# Altium schematic sheet STC32G&STC8H Core.SchDoc
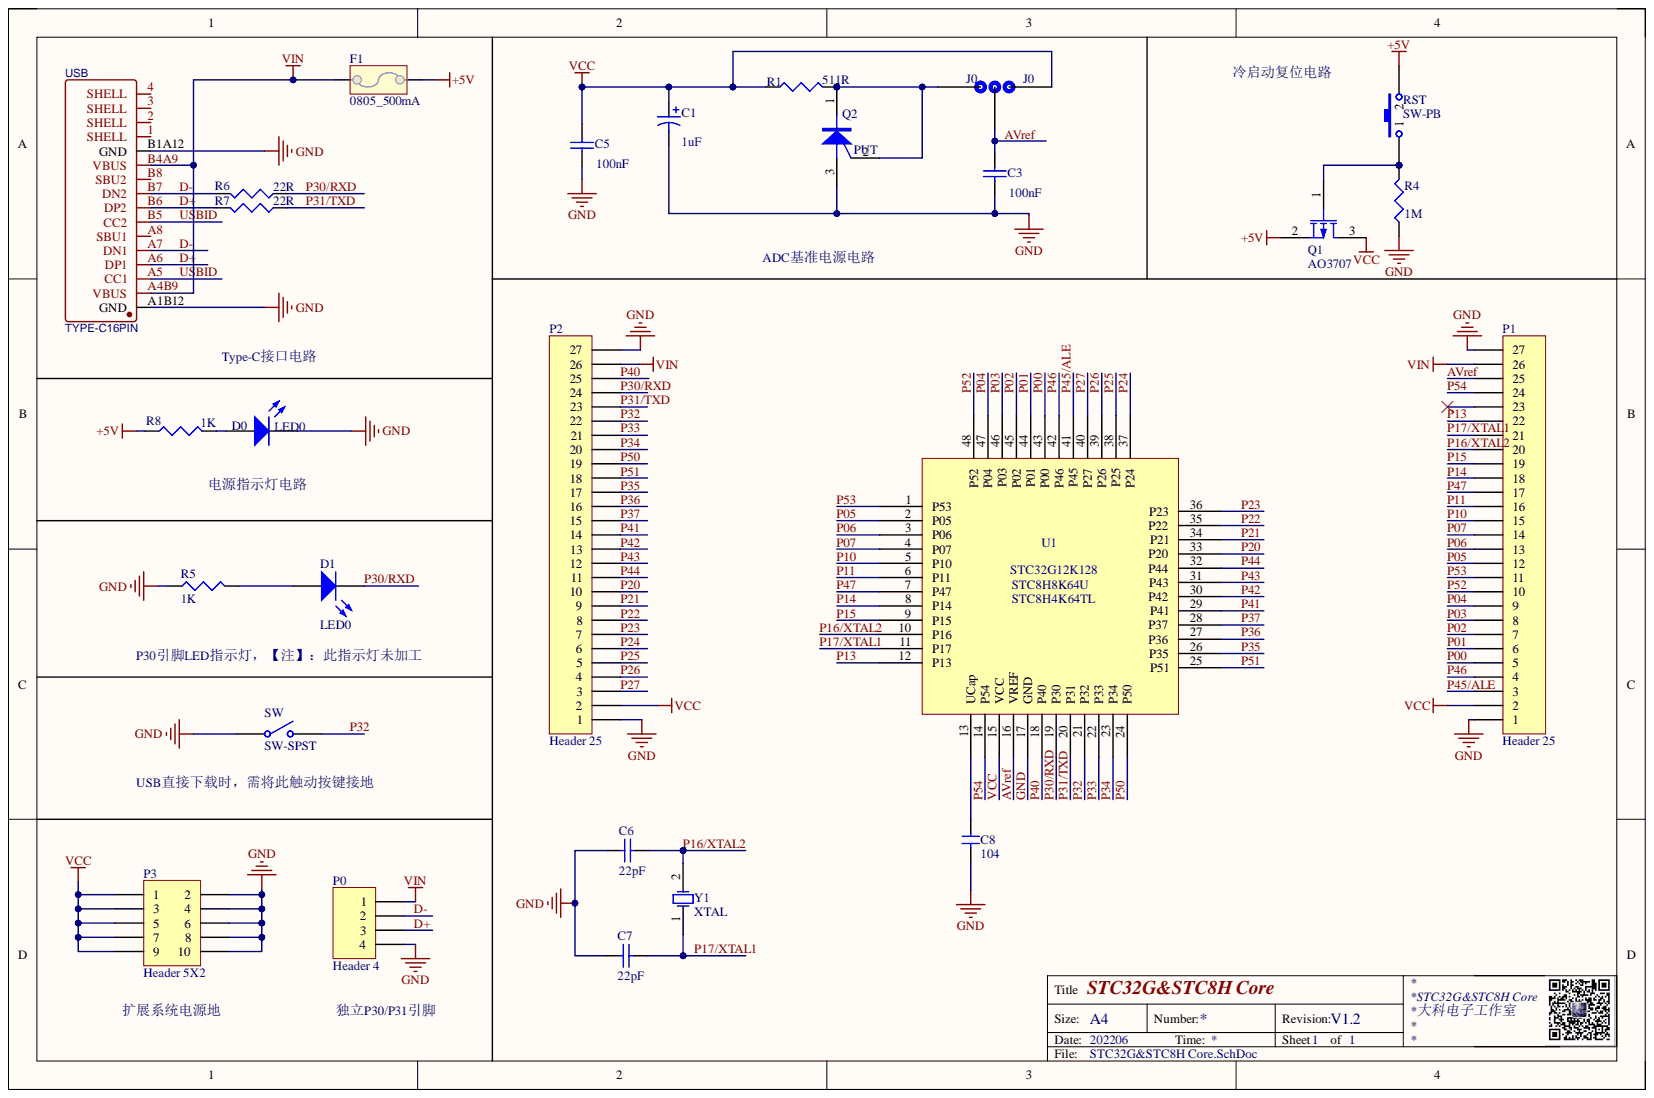
[diagram p0: STC32G&STC8H Core.SchDoc]

## Parts
C1  value 1uF  [C-RAD-0805]
C3  value 100nF  [C-RAD-0805]
C5  value 100nF  [C-RAD-0805]
C6  value 22pF  [C-RAD-0805]  (hidden comment: Cap)
C7  22pF  [C-RAD-0805]
C8  104  [C-RAD-0805]
D0  LED0  [LED_0805]
D1  LED0  [LED_0805]
F1  0805_500mA  [1206C]
J0  J0  [3-PIN]
P0  Header 4  [HDR1X4]
P1  Header 25  [HDR1X27]
P2  Header 25  [HDR1X27]
P3  Header 5X2  [HDR2X5-]
Q1  AO3707  [SOT-23]
Q2  PUT  [SOT-23]
R1  value 511R  [0805-1]
R4  value 1M  [0805-1]
R5  value 1K  [0805-1]
R6  value 22R  [0805-1]
R7  value 22R  [0805-1]
R8  value 1K  [0805-1]
RST  SW-PB  [SW 3X4X2MM]
SW  SW-SPST  [SW 3X4X2MM]
U1  STC32G12K128  [LQFP48]
USB  TYPE-C16PIN  [TYPE-C]
Y1  XTAL  [X-SM49]

## Nets
+5V: F1.2 Q1.2 R8.1 RST.2
VIN: F1.1 P0.1 P1.26 P2.26 USB.A4B9(VBUS) USB.B4A9(VBUS)
AVref: C3.2 J0.2 P1.25 U1.16(VREF)
D+: P0.3 R7.2 USB.A6(DP1) USB.B6(DP2)
D-: P0.2 R6.2 USB.A7(DN1) USB.B7(DN2)
GND: C1.2 C3.1 C5.1 C6.1 C7.1 C8.2 D0.2 P0.4 P1.1 P1.27 P2.1 P2.27 P3.2 P3.4 P3.6 P3.8 P3.10 Q2.3 R4.1 R5.1 SW.1 U1.17(GND) USB.A1B12(GND) USB.B1A12(GND)
P00: P1.5 U1.43(P00)
P01: P1.6 U1.44(P01)
P02: P1.7 U1.45(P02)
P03: P1.8 U1.46(P03)
P04: P1.9 U1.47(P04)
P05: P1.12 U1.2(P05)
P06: P1.13 U1.3(P06)
P07: P1.14 U1.4(P07)
P10: P1.15 U1.5(P10)
P11: P1.16 U1.6(P11)
P13: P1.22 U1.12(P13)
P14: P1.18 U1.8(P14)
P15: P1.19 U1.9(P15)
P16/XTAL2: C6.2 P1.20 U1.10(P16) Y1.2
P17/XTAL1: C7.2 P1.21 U1.11(P17) Y1.1
P20: P2.10 U1.33(P20)
P21: P2.9 U1.34(P21)
P22: P2.8 U1.35(P22)
P23: P2.7 U1.36(P23)
P24: P2.6 U1.37(P24)
P25: P2.5 U1.38(P25)
P26: P2.4 U1.39(P26)
P27: P2.3 U1.40(P27)
P30/RXD: D1.2 P2.24 R6.1 U1.19(P30)
P31/TXD: P2.23 R7.1 U1.20(P31)
P32: P2.22 SW.2 U1.21(P32)
P33: P2.21 U1.22(P33)
P34: P2.20 U1.23(P34)
P35: P2.17 U1.26(P35)
P36: P2.16 U1.27(P36)
P37: P2.15 U1.28(P37)
P40: P2.25 U1.18(P40)
P41: P2.14 U1.29(P41)
P42: P2.13 U1.30(P42)
P43: P2.12 U1.31(P43)
P44: P2.11 U1.32(P44)
P45/ALE: P1.3 U1.41(P45)
P46: P1.4 U1.42(P46)
P47: P1.17 U1.7(P47)
P50: P2.19 U1.24(P50)
P51: P2.18 U1.25(P51)
P52: P1.10 U1.48(P52)
P53: P1.11 U1.1(P53)
P54: P1.24 U1.14(P54)
USBID: USB.A5(CC1) USB.B5(CC2)
VCC: C1.1 C5.2 J0.1 P1.2 P2.2 P3.1 P3.3 P3.5 P3.7 P3.9 Q1.3 R1.1 U1.15(VCC)
NetC8_1: C8.1 U1.13(UCap)
NetD0_1: D0.1 R8.2
NetD1_1: D1.1 R5.2
NetJ0_3: J0.3 Q2.1 Q2.2 R1.2
NetQ1_1: Q1.1 R4.2 RST.1

Not connected: P1 23; USB 1(SHELL) 2(SHELL) 3(SHELL) 4(SHELL) A8(SBU1) B8(SBU2)

## Library data of the parts
C1 = Cap Pol1: Polarized Capacitor (Radial); Component Kind: Standard; LatestRevisionDate: 17-Jul-2002; LatestRevisionNote: Re-released for DXP Platform.; Library Name: Miscellaneous Devices.IntLib; PackageDescription: Polarized Capacitor (Radial); 2 Leads; PackageReference: RB7.6-15; Published: 8-Jun-2000; Signal Integrity: Cap Pol; Simulation: CAP; Publisher: Altium Limited
C3 C5 = Cap: Capacitor; Component Kind: Standard; LatestRevisionDate: 17-Jul-2002; LatestRevisionNote: Re-released for DXP Platform.; Library Name: Miscellaneous Devices.IntLib; PackageDescription: Radial Cap, Thru-Hole; 2 Leads; 0.3 in Pin Spacing; PackageReference: RAD-0.3; Published: 8-Jun-2000; Signal Integrity: Cap; Simulation: CAP; Publisher: Altium Limited
C6 C7 = Cap: 无极性电容; Component Kind: Standard; LatestRevisionDate: 17-Jul-2002; LatestRevisionNote: Re-released for DXP Platform.; PackageReference: RAD-0.3; Published: 8-Jun-2000; Library Name: Fszhang.IntLib; Publisher: Altium Limited
  C7: Value: 22pF
C8 = CAP CN: Component Kind: Standard
D0 D1 = LED0: Typical INFRARED GaAs LED; Component Kind: Standard; LatestRevisionDate: 08-Jul-2005; LatestRevisionNote: Mech Layer 1 removed.; Library Name: Miscellaneous Devices.IntLib; PackageDescription: LED; 2 Leads; PackageReference: LED-0; Published: 8-Jun-2000; Simulation: LED0; Publisher: Altium Limited
F1 = Fuse: Component Kind: Standard; Description: Fuse; Library Name: 安中医库2.0.SCHLIB
J0 = 3-Pin: Component Kind: Standard; Library Name: Type-C 6Pin.SchLib
P0 = Header 4: Header, 4-Pin; Component Kind: Standard; LatestRevisionDate: 17-Jul-2002; LatestRevisionNote: Re-released for DXP Platform.; Library Name: Miscellaneous Connectors.IntLib; Signal Integrity: Connector; Publisher: Altium Limited
P1 P2 = Header 25: Header, 25-Pin; Component Kind: Standard; LatestRevisionDate: 17-Jul-2002; LatestRevisionNote: Re-released for DXP Platform.; Library Name: STC8G_Core.SCHLIB; Signal Integrity: Connector; Publisher: Altium Limited
P3 = Header 5X2: Header, 5-Pin, Dual row; Component Kind: Standard; LatestRevisionDate: 17-Jul-2002; LatestRevisionNote: Re-released for DXP Platform.; Library Name: Miscellaneous Connectors.IntLib; Signal Integrity: Connector; Publisher: Altium Limited
Q1 = MOSFET-P: P-Channel MOSFET; Component Kind: Standard; LatestRevisionDate: 17-Jul-2002; LatestRevisionNote: Re-released for DXP Platform.; Library Name: Miscellaneous Devices.IntLib; PackageDescription: TO, Flat Index; 3 In-Line, Axial Leads; Body Dia. 4.6mm; Lead Dia. 0.5 mm (max); PackageReference: E3; PackageVersion: 1995/1996; Published: 8-Jun-2000; Simulation: PMOS; Publisher: Altium Limited
Q2 = PUT: Programmable Unijunction Transistor; Component Kind: Standard; LatestRevisionDate: 17-Jul-2002; LatestRevisionNote: Re-released for DXP Platform.; Library Name: Miscellaneous Devices.IntLib; PackageDescription: Can; 3 Leads; Pin Circle Dia. 2.54 mm; Lead Dia. 0.48 mm (max); PackageReference: TO-52; Published: 8-Jun-2000; Simulation: PUT; Publisher: Altium Limited
R1 R5 R8 = Res3: Resistor; Component Kind: Standard; LatestRevisionDate: 29-May-2009; LatestRevisionNote: IPC-7351 Footprint Added.; Library Name: Miscellaneous Devices.IntLib; PackageDescription: Chip Resistor; PackageReference: J1-0603; Published: 8-Jun-2000; Signal Integrity: Res; Simulation: RESISTOR; Publisher: Altium Limited
R4 R6 R7 = Res3: 1M; Component Kind: Standard; LatestRevisionDate: 29-May-2009; LatestRevisionNote: IPC-7351 Footprint Added.; Library Name: Miscellaneous Devices.IntLib; PackageDescription: Chip Resistor; PackageReference: J1-0603; Published: 8-Jun-2000; Signal Integrity: Res; Simulation: RESISTOR; Publisher: Altium Limited
RST = SW-PB: Switch; Component Kind: Standard; LatestRevisionDate: 17-Jul-2002; LatestRevisionNote: Re-released for DXP Platform.; Library Name: Miscellaneous Devices.IntLib; PackageDescription: Switch; 2 Leads; PackageReference: SPST-2; Published: 24-Mar-1999; Publisher: Altium Limited
SW = SW-SPST: Single-Pole, Single-Throw Switch; Component Kind: Standard; LatestRevisionDate: 17-Jul-2002; LatestRevisionNote: Re-released for DXP Platform.; Library Name: Miscellaneous Devices.IntLib; PackageDescription: Switch; 2 Leads; PackageReference: SPST-2; Published: 24-Mar-1999; Publisher: Altium Limited
U1 = STC32G12K128 LQFP48: Component Kind: Standard; Library Name: STC_Study_15Fxx.SCHLIB
USB = TYPE-C16PIN: BOM_Manufacturer: SHOU HAN; Contributor: LCSC; BOM_Manufacturer Part: TYPE-C16PIN; BOM_Supplier: LCSC; BOM_Supplier Part: C393939; Component Kind: Standard; spicePre: U
Y1 = XTAL: Crystal Oscillator; Component Kind: Standard; LatestRevisionDate: 17-Jul-2002; LatestRevisionNote: Re-released for DXP Platform.; Library Name: Miscellaneous Devices.IntLib; PackageDescription: Crystal, Thru-Hole; 2 Leads; Body 3.1 x 8.2 mm (Dia.xH); PackageReference: R38; Published: 8-Jun-2000; Simulation: XTAL; Publisher: Altium Limited
